# Altium schematic sheet ports.SchDoc
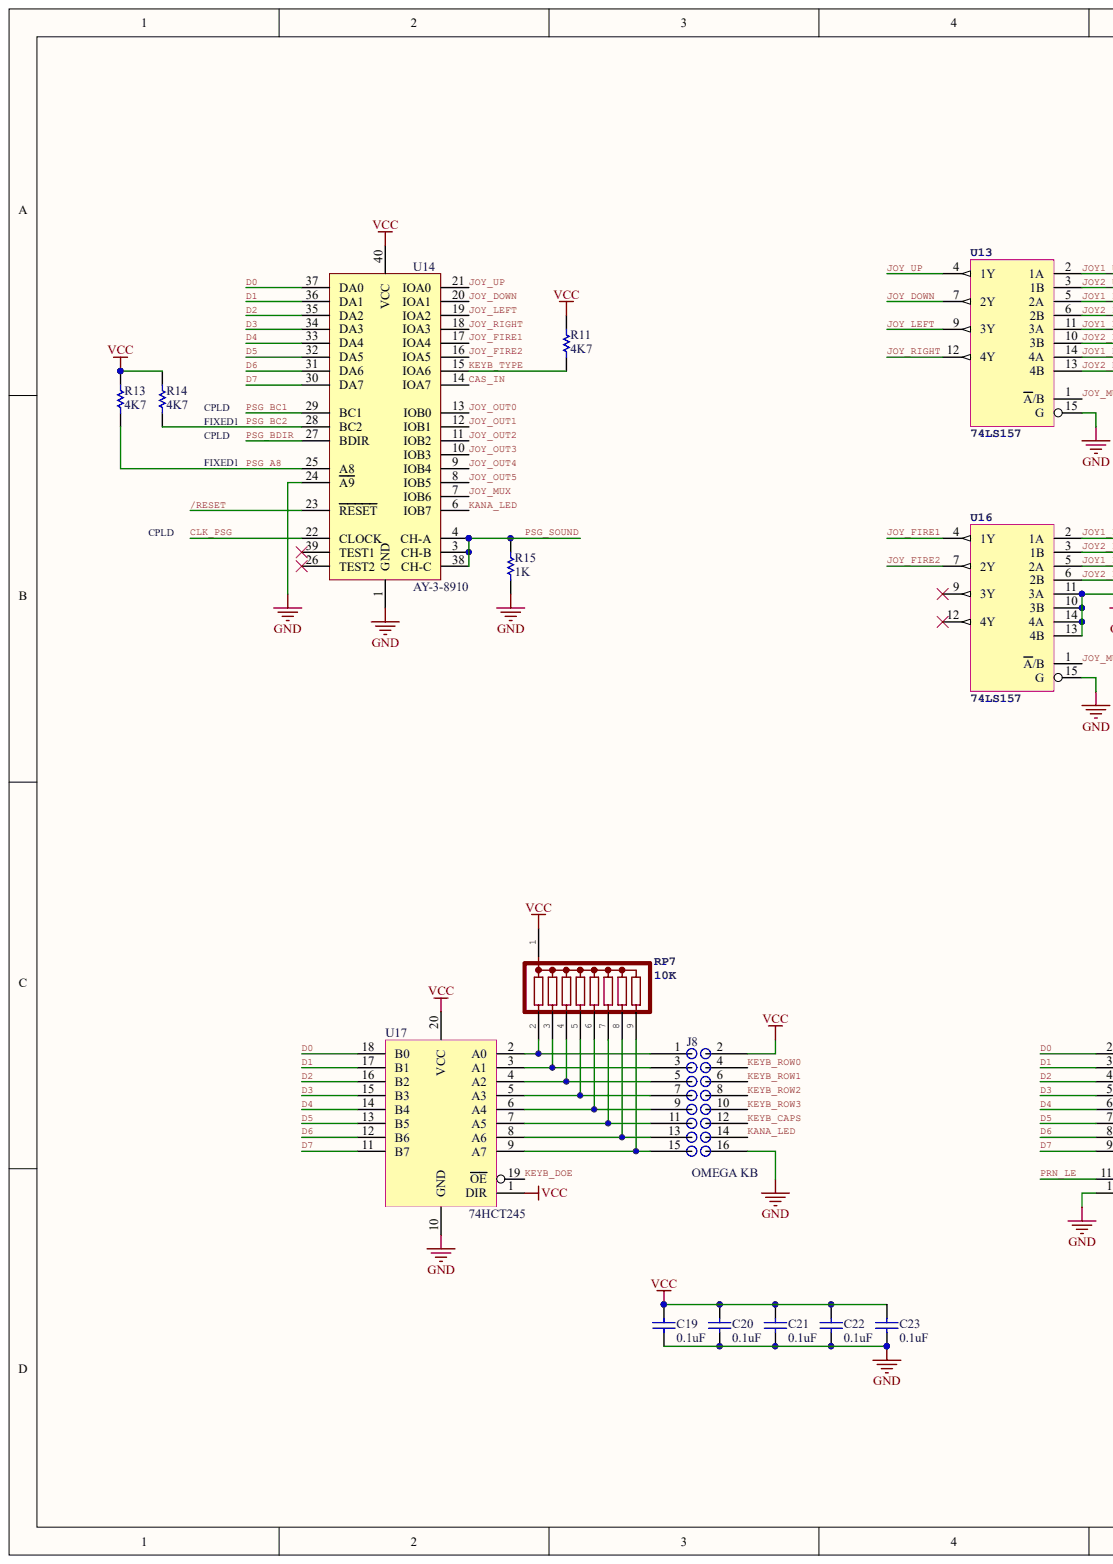
[diagram p0: ports.SchDoc, left]
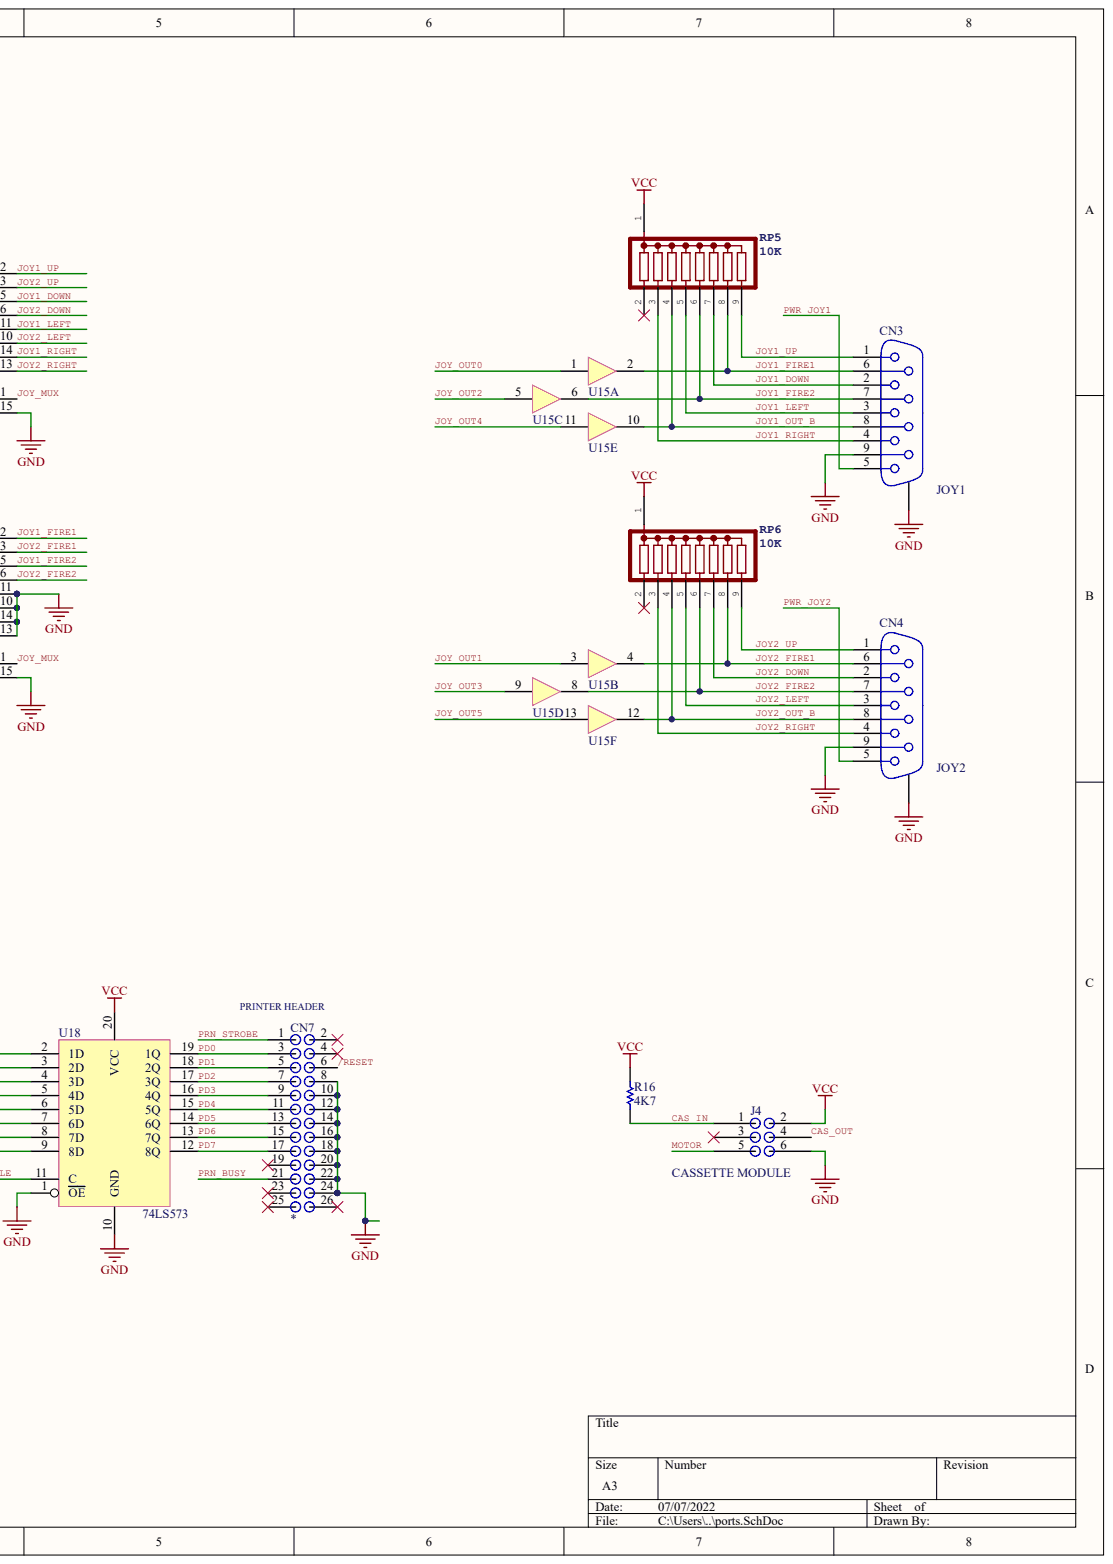
[diagram p1: ports.SchDoc, right]

## Parts
C19  0.1uF
C20  0.1uF
C21  0.1uF
C22  0.1uF
C23  0.1uF
CN3  JOY1
CN4  JOY2
CN7  *
J4  CASSETTE MODULE
J8  OMEGA KB
R11  4K7
R13  4K7
R14  4K7
R15  1K
R16  4K7
RP5  10K
RP6  10K
RP7  10K
U13  74LS157
U14  AY-3-8910
U15  SN74LVC07A  (value not drawn)  (parts A,B,C,D,E,F)
U16  74LS157
U17  74HCT245
U18  74LS573

## Nets
GND: C19.1 C20.1 C21.1 C22.1 C23.1 CN3.0 CN3.9 CN4.0 CN4.9 CN7.8 CN7.10 CN7.12 CN7.14 CN7.16 CN7.18 CN7.20 CN7.22 CN7.24 J4.6 J8.16 R15.2 U13.8 U13.15(G) U14.1(GND) U14.24(A9) U15.7 U16.8 U16.10(3B) U16.11(3A) U16.13(4B) U16.14(4A) U16.15(G) U17.10(GND) U18.1(OE) U18.10(GND)
VCC: C19.2 C20.2 C21.2 C22.2 C23.2 J4.2 J8.2 R11.1 R13.1 R14.1 R16.1 RP5.1 RP6.1 RP7.1 U13.16 U14.40(VCC) U15.14 U16.16 U17.1(DIR) U17.20(VCC) U18.20(VCC)
/RESET: CN7.6 U14.23(RESET)
CAS_IN: J4.1 R16.2 U14.14(IOA7)
CAS_OUT: J4.4
CLK_PSG: U14.22(CLOCK)
D0: U14.37(DA0) U17.18(B0) U18.2(1D)
D1: U14.36(DA1) U17.17(B1) U18.3(2D)
D2: U14.35(DA2) U17.16(B2) U18.4(3D)
D3: U14.34(DA3) U17.15(B3) U18.5(4D)
D4: U14.33(DA4) U17.14(B4) U18.6(5D)
D5: U14.32(DA5) U17.13(B5) U18.7(6D)
D6: U14.31(DA6) U17.12(B6) U18.8(7D)
D7: U14.30(DA7) U17.11(B7) U18.9(8D)
JOY1_DOWN: CN3.2 RP5.7 U13.5(2A)
JOY1_FIRE1: CN3.6 RP5.8 U15.2 U16.2(1A)
JOY1_FIRE2: CN3.7 RP5.6 U15.6 U16.5(2A)
JOY1_LEFT: CN3.3 RP5.5 U13.11(3A)
JOY1_OUT_B: CN3.8 RP5.4 U15.10
JOY1_RIGHT: CN3.4 RP5.3 U13.14(4A)
JOY1_UP: CN3.1 RP5.9 U13.2(1A)
JOY2_DOWN: CN4.2 RP6.7 U13.6(2B)
JOY2_FIRE1: CN4.6 RP6.8 U15.4 U16.3(1B)
JOY2_FIRE2: CN4.7 RP6.6 U15.8 U16.6(2B)
JOY2_LEFT: CN4.3 RP6.5 U13.10(3B)
JOY2_OUT_B: CN4.8 RP6.4 U15.12
JOY2_RIGHT: CN4.4 RP6.3 U13.13(4B)
JOY2_UP: CN4.1 RP6.9 U13.3(1B)
JOY_DOWN: U13.7(2Y) U14.20(IOA1)
JOY_FIRE1: U14.17(IOA4) U16.4(1Y)
JOY_FIRE2: U14.16(IOA5) U16.7(2Y)
JOY_LEFT: U13.9(3Y) U14.19(IOA2)
JOY_MUX: U13.1(A/B) U14.7(IOB6) U16.1(A/B)
JOY_OUT0: U14.13(IOB0) U15.1
JOY_OUT1: U14.12(IOB1) U15.3
JOY_OUT2: U14.11(IOB2) U15.5
JOY_OUT3: U14.10(IOB3) U15.9
JOY_OUT4: U14.9(IOB4) U15.11
JOY_OUT5: U14.8(IOB5) U15.13
JOY_RIGHT: U13.12(4Y) U14.18(IOA3)
JOY_UP: U13.4(1Y) U14.21(IOA0)
KANA_LED: J8.14 U14.6(IOB7)
KEYB_CAPS: J8.12
KEYB_DOE: U17.19(OE)
KEYB_ROW0: J8.4
KEYB_ROW1: J8.6
KEYB_ROW2: J8.8
KEYB_ROW3: J8.10
KEYB_TYPE: R11.2 U14.15(IOA6)
MOTOR: J4.5
PD0: CN7.3 U18.19(1Q)
PD1: CN7.5 U18.18(2Q)
PD2: CN7.7 U18.17(3Q)
PD3: CN7.9 U18.16(4Q)
PD4: CN7.11 U18.15(5Q)
PD5: CN7.13 U18.14(6Q)
PD6: CN7.15 U18.13(7Q)
PD7: CN7.17 U18.12(8Q)
PRN_BUSY: CN7.21
PRN_LE: U18.11(C)
PRN_STROBE: CN7.1
PSG_A8: R13.2 U14.25(A8)
PSG_BC1: U14.29(BC1)
PSG_BC2: R14.2 U14.28(BC2)
PSG_BDIR: U14.27(BDIR)
PSG_SOUND: R15.1 U14.3(CH-B) U14.4(CH-A) U14.38(CH-C)
PWR_JOY1: CN3.5
PWR_JOY2: CN4.5
NetJ8_1: J8.1 RP7.2 U17.2(A0)
NetJ8_3: J8.3 RP7.3 U17.3(A1)
NetJ8_5: J8.5 RP7.4 U17.4(A2)
NetJ8_7: J8.7 RP7.5 U17.5(A3)
NetJ8_9: J8.9 RP7.6 U17.6(A4)
NetJ8_11: J8.11 RP7.7 U17.7(A5)
NetJ8_13: J8.13 RP7.8 U17.8(A6)
NetJ8_15: J8.15 RP7.9 U17.9(A7)

Not connected: CN7 2 4 19 23 25 26; J4 3; RP5 2; RP6 2; U14 26(TEST2) 39(TEST1); U16 9(3Y) 12(4Y)

## Library data of the parts
CN3 CN4 = (no symbol name): PackageDocument: O; Positions: 9; Gender: Receptacle; Published: 15-Jun-2001; LatestRevisionDate: 17-Jul-2002; LatestRevisionNote: Re-released for DXP Platform.; DatasheetDocument: 1-Sep-1999; PackageReference: 788750; Orientation: Right Angle; Publisher: Altium Limited
C19-C23 CN7 J4 J8 R11 R13-R16 RP5-RP7 U13-U18 = (no symbol name)
  C19-C23: VALUE: 0.1uF
  CN7: PartNumber: *
  R11 R13 R14 R16: Value: 4K7
  R15: Value: 1K
  RP5-RP7: Value: 10K
  U13 U16: PartNumber: 74LS157
  U14: PartNumber: AY-3-8910
  U15: PartNumber: SN74LVC07A
  U17: VALUE: 74HCT245
  U18: VALUE: 74LS573
